# Altium schematic sheet CAN_IO.SchDoc
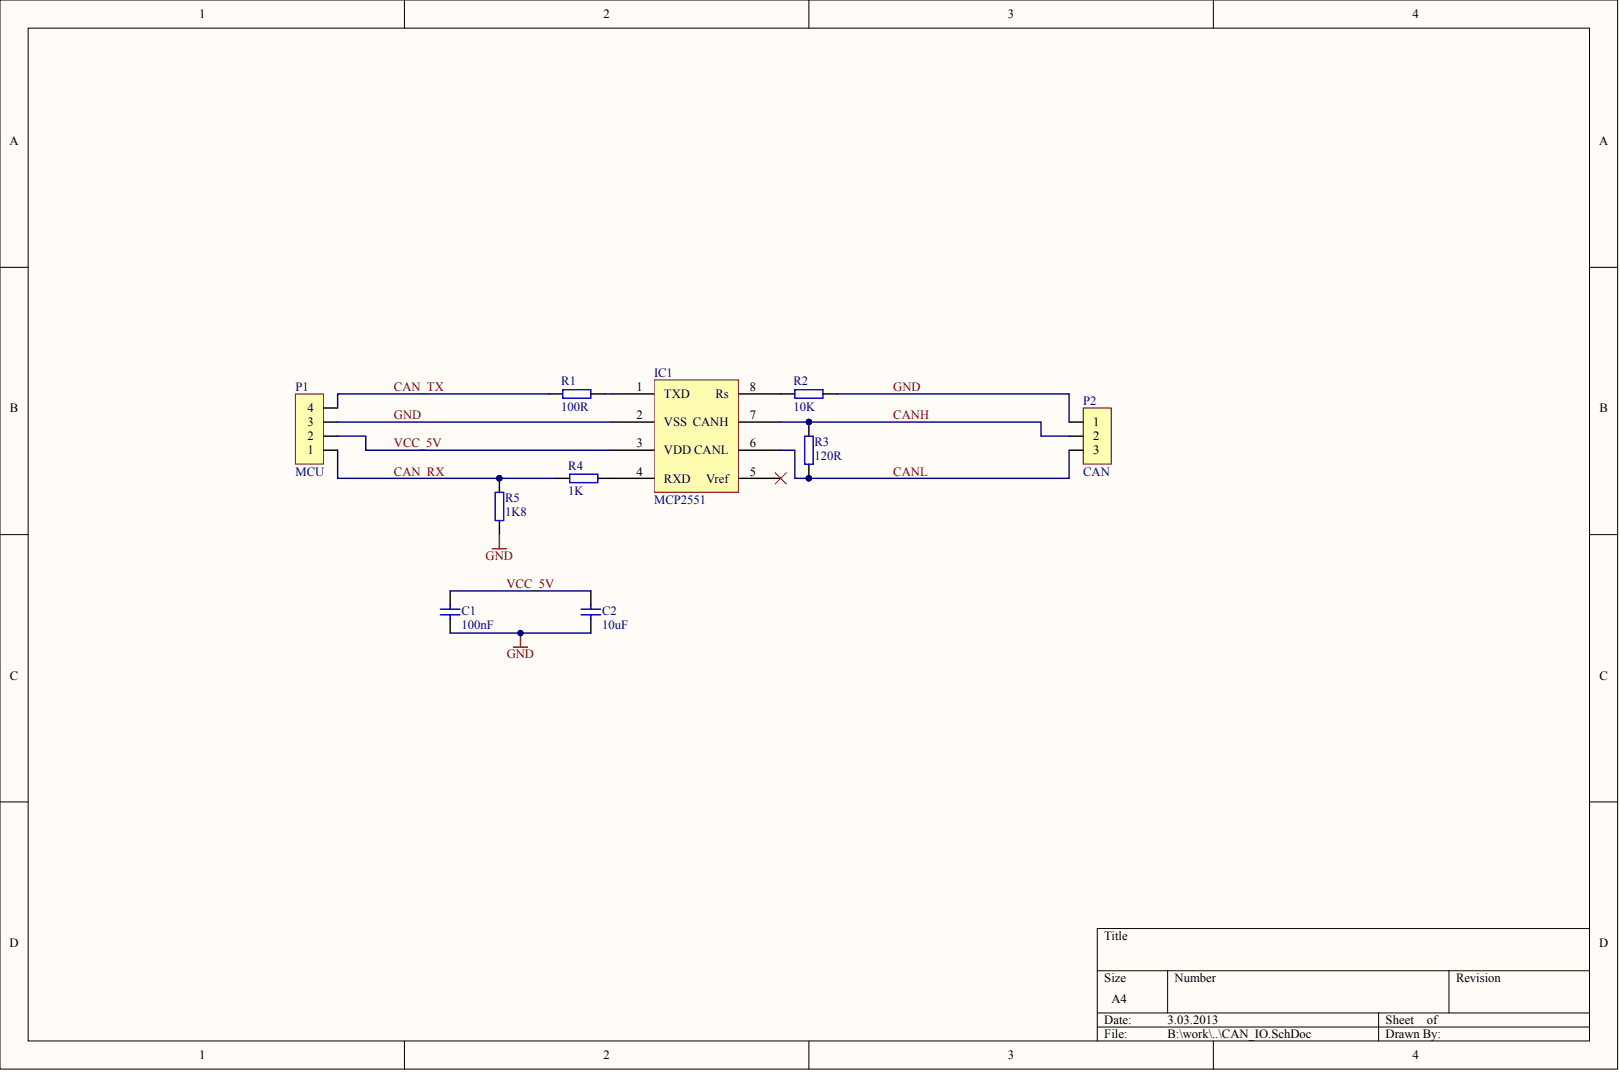
[diagram p0: CAN_IO.SchDoc]

## Parts
C1  100nF
C2  10uF
IC1  MCP2551
P1  MCU
P2  CAN
R1  100R
R2  10K
R3  120R
R4  1K
R5  1K8

## Nets
CAN_RX: P1.1 R4.1 R5.2
CAN_TX: P1.4 R1.1
CANH: IC1.7(CANH) P2.2 R3.1
CANL: IC1.6(CANL) P2.3 R3.2
GND: C1.1 C2.1 IC1.2(VSS) P1.3 P2.1 R2.2 R5.1
VCC_5V: C1.2 C2.2 IC1.3(VDD) P1.2
NetIC1_1: IC1.1(TXD) R1.2
NetIC1_4: IC1.4(RXD) R4.2
NetIC1_8: IC1.8(Rs) R2.1

Not connected: IC1 5(Vref)

## Library data of the parts
C1 = (no symbol name): ID: 2; Component: C0603; Value: 100nF; Case: 0603
C2 = (no symbol name): ID: 3; Component: C0805; Value: 10uF; FARNELL: 1845747; Case: 0805
P1 = (no symbol name): ID: 4; Component: con4p254; Value: MCU; Case: 1x4_254
P2 = (no symbol name): ID: 3; Component: con3p254; Value: CAN; Case: 1x3_254
R1-R5 = (no symbol name): ID: 2; Component: R0603; Case: 0603
  R1: Value: 100R
  R2: Value: 10K
  R3: Value: 120R
  R4: Value: 1K
  R5: Value: 1K8
IC1 = (no symbol name): Case: SOIC8; Value: MCP2551
